# Altium schematic sheet HDMI.SchDoc
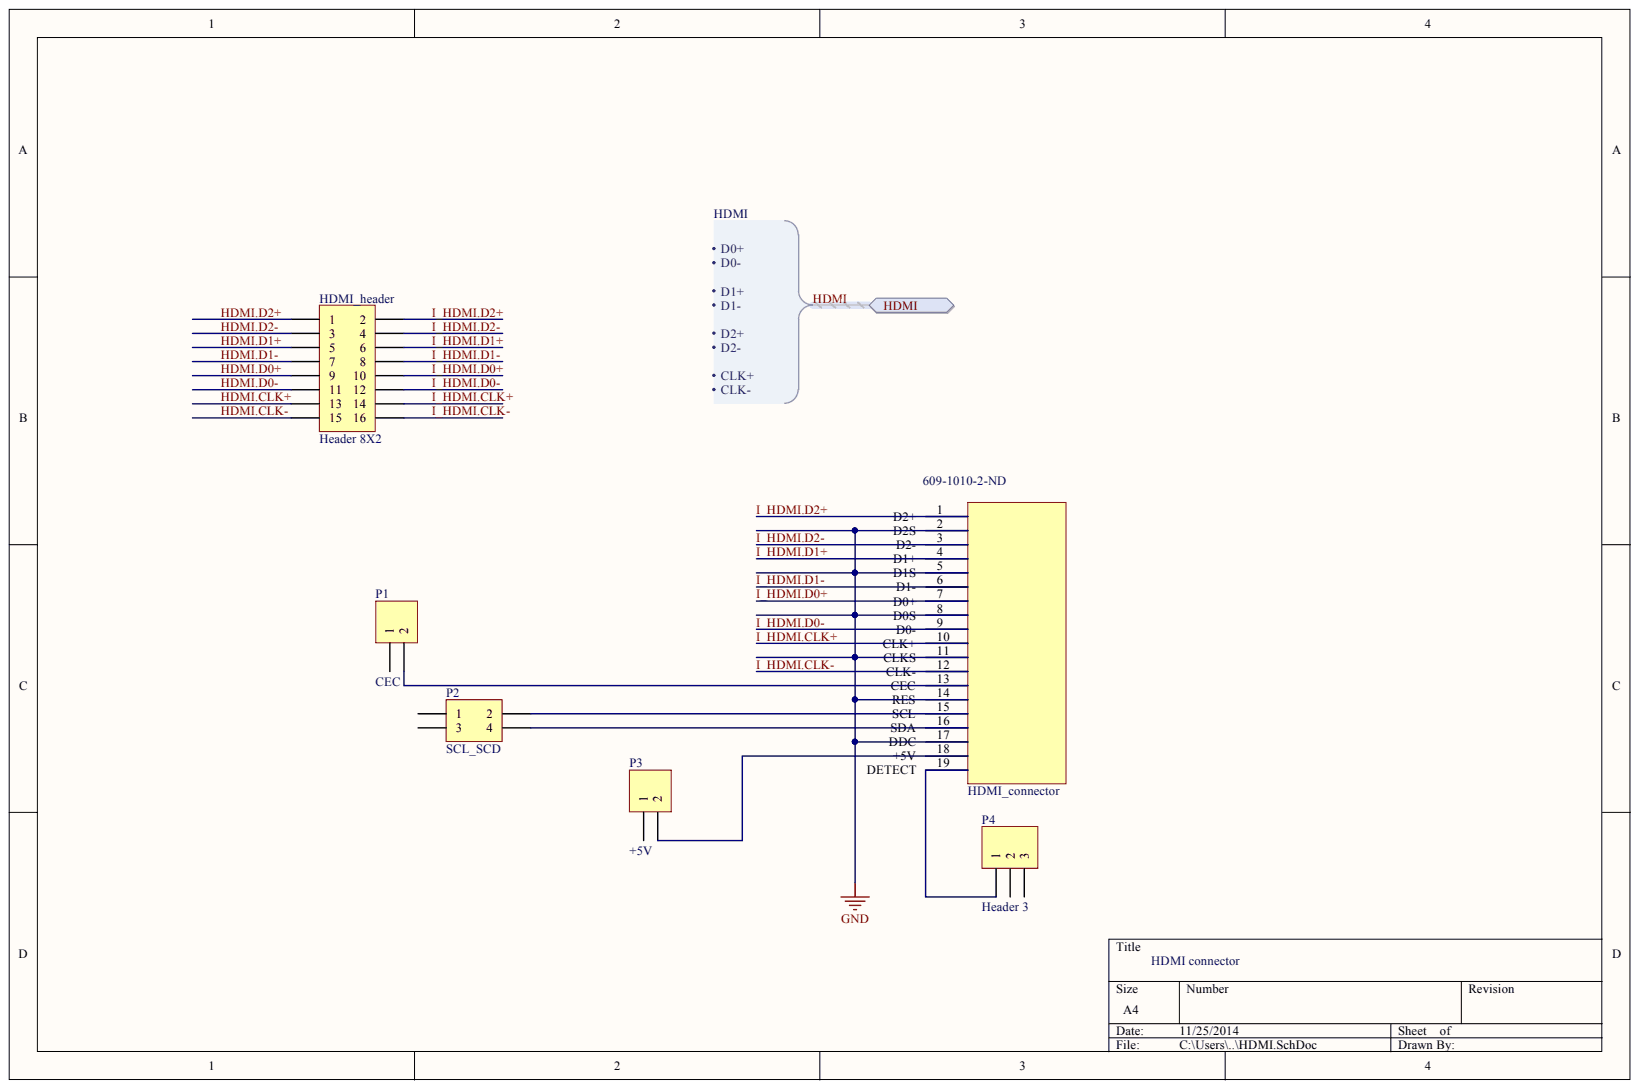
[diagram p0: HDMI.SchDoc]

## Parts
HDMI_header  Header 8X2  [HDR2X8]
P1  CEC  [HDR1X2]
P2  SCL_SCD  [HDR2X2]
P3  +5V  [HDR1X2]
P4  Header 3  [HDR1X3]
  HDMI_connector  Supplier Part Number 1: 609-1010-2-ND  [HDMI-type-A]

## Ports
HDMI, HDMI.CLK+, HDMI.CLK-, HDMI.D0+, HDMI.D0-, HDMI.D1+, HDMI.D1-, HDMI.D2+, HDMI.D2-

## Nets
GND: .2 .5 .8 .11 .14 .17
Net_13: P1.2 .13
Net_15: P2.2 .15
Net_16: P2.4 .16
Net_18: P3.2 .18
Net_19: P4.1 .19
HDMI.CLK+: HDMI_header.13 ; port HDMI
HDMI.CLK-: HDMI_header.15 ; port HDMI
HDMI.D0+: HDMI_header.9 ; port HDMI
HDMI.D0-: HDMI_header.11 ; port HDMI
HDMI.D1+: HDMI_header.5 ; port HDMI
HDMI.D1-: HDMI_header.7 ; port HDMI
HDMI.D2+: HDMI_header.1 ; port HDMI
HDMI.D2-: HDMI_header.3 ; port HDMI
I_HDMI.CLK+: HDMI_header.14 .10
I_HDMI.CLK-: HDMI_header.16 .12
I_HDMI.D0+: HDMI_header.10 .7
I_HDMI.D0-: HDMI_header.12 .9
I_HDMI.D1+: HDMI_header.6 .4
I_HDMI.D1-: HDMI_header.8 .6
I_HDMI.D2+: HDMI_header.2 .1
I_HDMI.D2-: HDMI_header.4 .3

Not connected: P1 1; P2 1 3; P3 1; P4 2 3

## Library data of the parts
HDMI_header = Header 8X2: Header, 8-Pin, Dual row; Component Kind: Standard; LatestRevisionDate: 17-Jul-2002; LatestRevisionNote: Re-released for DXP Platform.; Library Name: Miscellaneous Connectors.IntLib; Signal Integrity: Connector; Publisher: Altium Limited
P1 P3 = Header 2: Header, 2-Pin; Component Kind: Standard; LatestRevisionDate: 17-Jul-2002; LatestRevisionNote: Re-released for DXP Platform.; Library Name: Miscellaneous Connectors.IntLib; Signal Integrity: Connector; Publisher: Altium Limited
P2 = Header 2X2: Header, 2-Pin, Dual row; Component Kind: Standard; LatestRevisionDate: 17-Jul-2002; LatestRevisionNote: Re-released for DXP Platform.; Library Name: Miscellaneous Connectors.IntLib; Signal Integrity: Connector; Publisher: Altium Limited
P4 = Header 3: Header, 3-Pin; Component Kind: Standard; LatestRevisionDate: 17-Jul-2002; LatestRevisionNote: Re-released for DXP Platform.; Library Name: Miscellaneous Connectors.IntLib; Signal Integrity: Connector; Publisher: Altium Limited
 = HDMI_connector: Manufacturer: FCI; Manufacturer Part Number: 10029449-001RLF; Connector Type: HDMI; Gender: Receptacle; Number of Contacts: 19; Category: Connectors, Interconnects; Component Kind: Standard; ComponentLink1Description: http://portal.fciconnect.com/Comergent//fci/drawing/10029449.pdf; ComponentLink1URL: http://portal.fciconnect.com/Comergent//fci/drawing/10029449.pdf; Features: -; Ingress Protection: -; Library Name: HDMI.SchLib; Mounting Type: Surface Mount, Right Angle, Horizontal; Packaging: Tape & Reel (TR); Pricing 1: 400=0.69795, 800=0.61071, 1200=0.55837, 2000=0.52347, 2800=0.50601, 10000=0.46414, 20000=0.45367 (EUR); RoHS: RoHS Compliant; Specifications: -; Stock 1: 12400; Supplier 1: Digi-Key
